# Altium schematic sheet REUN.468349.001_PSU_Board_Connectors.SchDoc
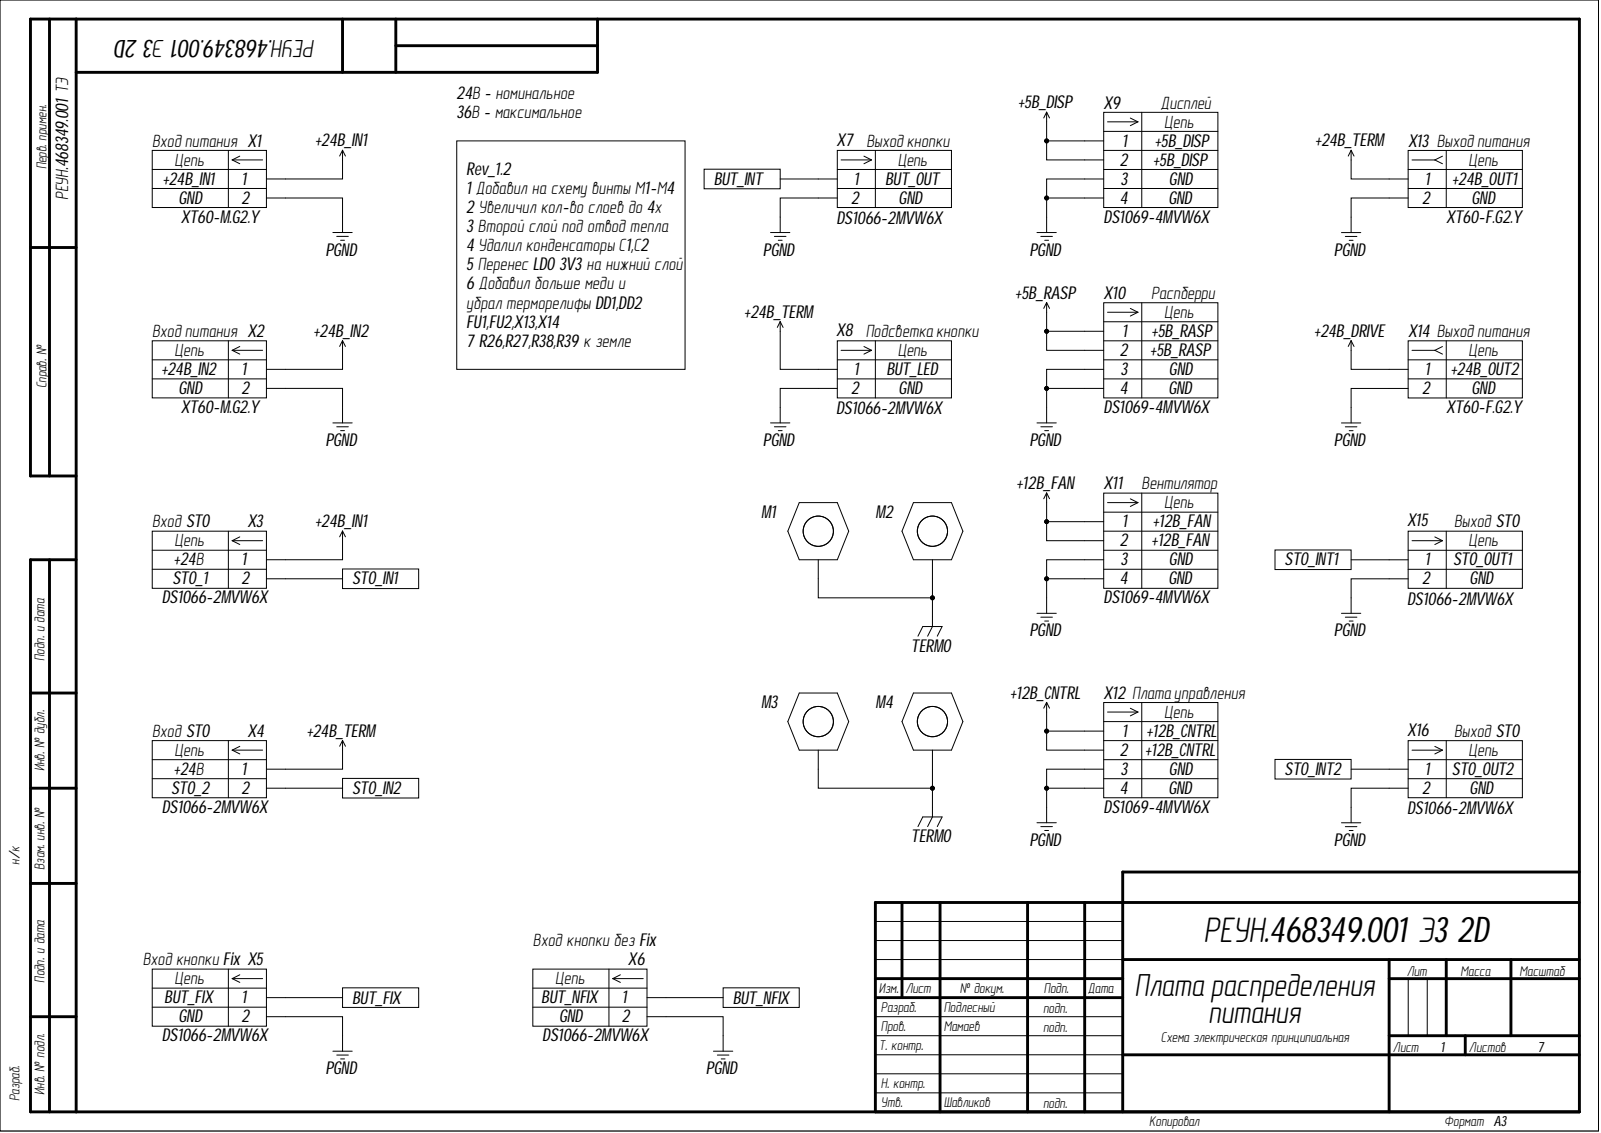
[diagram p0: REUN.468349.001_PSU_Board_Connectors.SchDoc]

## Parts
M1  SCREW  [SCREW3.5]  (value not drawn)
M2  SCREW  [SCREW3.5]  (value not drawn)
M3  SCREW  [SCREW3.5]  (value not drawn)
M4  SCREW  [SCREW3.5]  (value not drawn)
X1  XT60-M.G2.Y  Contact_1: +24B_IN1  Contact_2: GND  [XT60-M_TH]
X2  XT60-M.G2.Y  Contact_1: +24B_IN2  Contact_2: GND  [XT60-M_TH]
X3  DS1066-2MVW6X  Contact_1: +24В  Contact_2: STO_1  [MW-2M]
X4  DS1066-2MVW6X  Contact_1: +24В  Contact_2: STO_2  [MW-2M]
X5  DS1066-2MVW6X  Contact_1: BUT_FIX  Contact_2: GND  [MW-2M]
X6  DS1066-2MVW6X  Contact_1: BUT_NFIX  Contact_2: GND  [MW-2M]
X7  DS1066-2MVW6X  Contact_1: BUT_OUT  Contact_2: GND  [MW-2M]
X8  DS1066-2MVW6X  Contact_1: BUT_LED  Contact_2: GND  [MW-2M]
X9  DS1069-4MVW6X  Contact_1: +5B_DISP  Contact_3: GND  [CWF-4]
X10  DS1069-4MVW6X  Contact_1: +5B_RASP  Contact_3: GND  [CWF-4]
X11  DS1069-4MVW6X  Contact_1: +12B_FAN  Contact_3: GND  [CWF-4]
X12  DS1069-4MVW6X  Contact_1: +12B_CNTRL  Contact_3: GND  [CWF-4]
X13  XT60-F.G2.Y  Contact_1: +24B_OUT1  Contact_2: GND  [XT60-F_TH]
X14  XT60-F.G2.Y  Contact_1: +24B_OUT2  Contact_2: GND  [XT60-F_TH]
X15  DS1066-2MVW6X  Contact_1: STO_OUT1  Contact_2: GND  [MW-2M]
X16  DS1066-2MVW6X  Contact_1: STO_OUT2  Contact_2: GND  [MW-2M]

## Ports
BUT_FIX, BUT_INT, BUT_NFIX, STO_IN1, STO_IN2, STO_INT1, STO_INT2

## Nets
+5B_DISP: X9.1 X9.2
+5B_RASP: X10.1 X10.2
+12B_CNTRL: X12.1 X12.2
+12B_FAN: X11.1 X11.2
+24B_DRIVE: X14.1
+24B_IN1: X1.1 X3.1
+24B_IN2: X2.1
+24B_TERM: X4.1 X8.1 X13.1
PGND: X1.2 X2.2 X5.2 X6.2 X7.2 X8.2 X9.3 X9.4 X10.3 X10.4 X11.3 X11.4 X12.3 X12.4 X13.2 X14.2 X15.2 X16.2
TERMO: M1.1 M2.1 M3.1 M4.1
BUT_FIX: X5.1 ; port BUT_FIX
BUT_INT: X7.1 ; port BUT_INT
BUT_NFIX: X6.1 ; port BUT_NFIX
STO_IN1: X3.2 ; port STO_IN1
STO_IN2: X4.2 ; port STO_IN2
STO_INT1: X15.1 ; port STO_INT1
STO_INT2: X16.1 ; port STO_INT2

## Library data of the parts
X1 X2 = connect216: Разъем - 2 конт. вилка; Manufacture: Amass Electronics; Part Name: XT60-M; Database Table Name: Connector$; Etch: 7.2mm; Component Kind: Standard; id: connect216; Library Name: MOP44.DbLib; Part Number: XT60-M.G2.Y
X3-X8 X15 X16 = connect76: Разъем - 2 конт. вилка; Manufacture: Ningbo connfly electronic; Part Name: Вилка на плату прямая 2мм 2pin; Database Table Name: Connector$; Etch: 2mm; Component Kind: Standard; id: connect76; Library Name: MOP44.DbLib; Part Number: DS1066-2MVW6X
X9-X12 = connect233: Разъем - 4 конт. вилка; Manufacture: Connfly electronic; Part Name: Вилка прямая на плату; Database Table Name: Connector$; Etch: 2.5мм; Component Kind: Standard; Contact_4: GND; id: connect233; Library Name: MOP44.DbLib; Part Number: DS1069-4MVW6X
  X9: Contact_2: +5B_DISP
  X10: Contact_2: +5B_RASP
  X11: Contact_2: +12B_FAN
  X12: Contact_2: +12B_CNTRL
X13 X14 = connect215: Разъем - 2 конт. розетка; Manufacture: Amass Electronics; Part Name: XT60-F; Database Table Name: Connector$; Etch: 7.2mm; Component Kind: Standard; id: connect215; Library Name: MOP44.DbLib; Part Number: XT60-F.G2.Y
M1-M4 = SCREW: Component Kind: Standard; Library Name: REUN.468349.001_PSU_Board.SchLib
